# Altium schematic sheet Connector.SchDoc
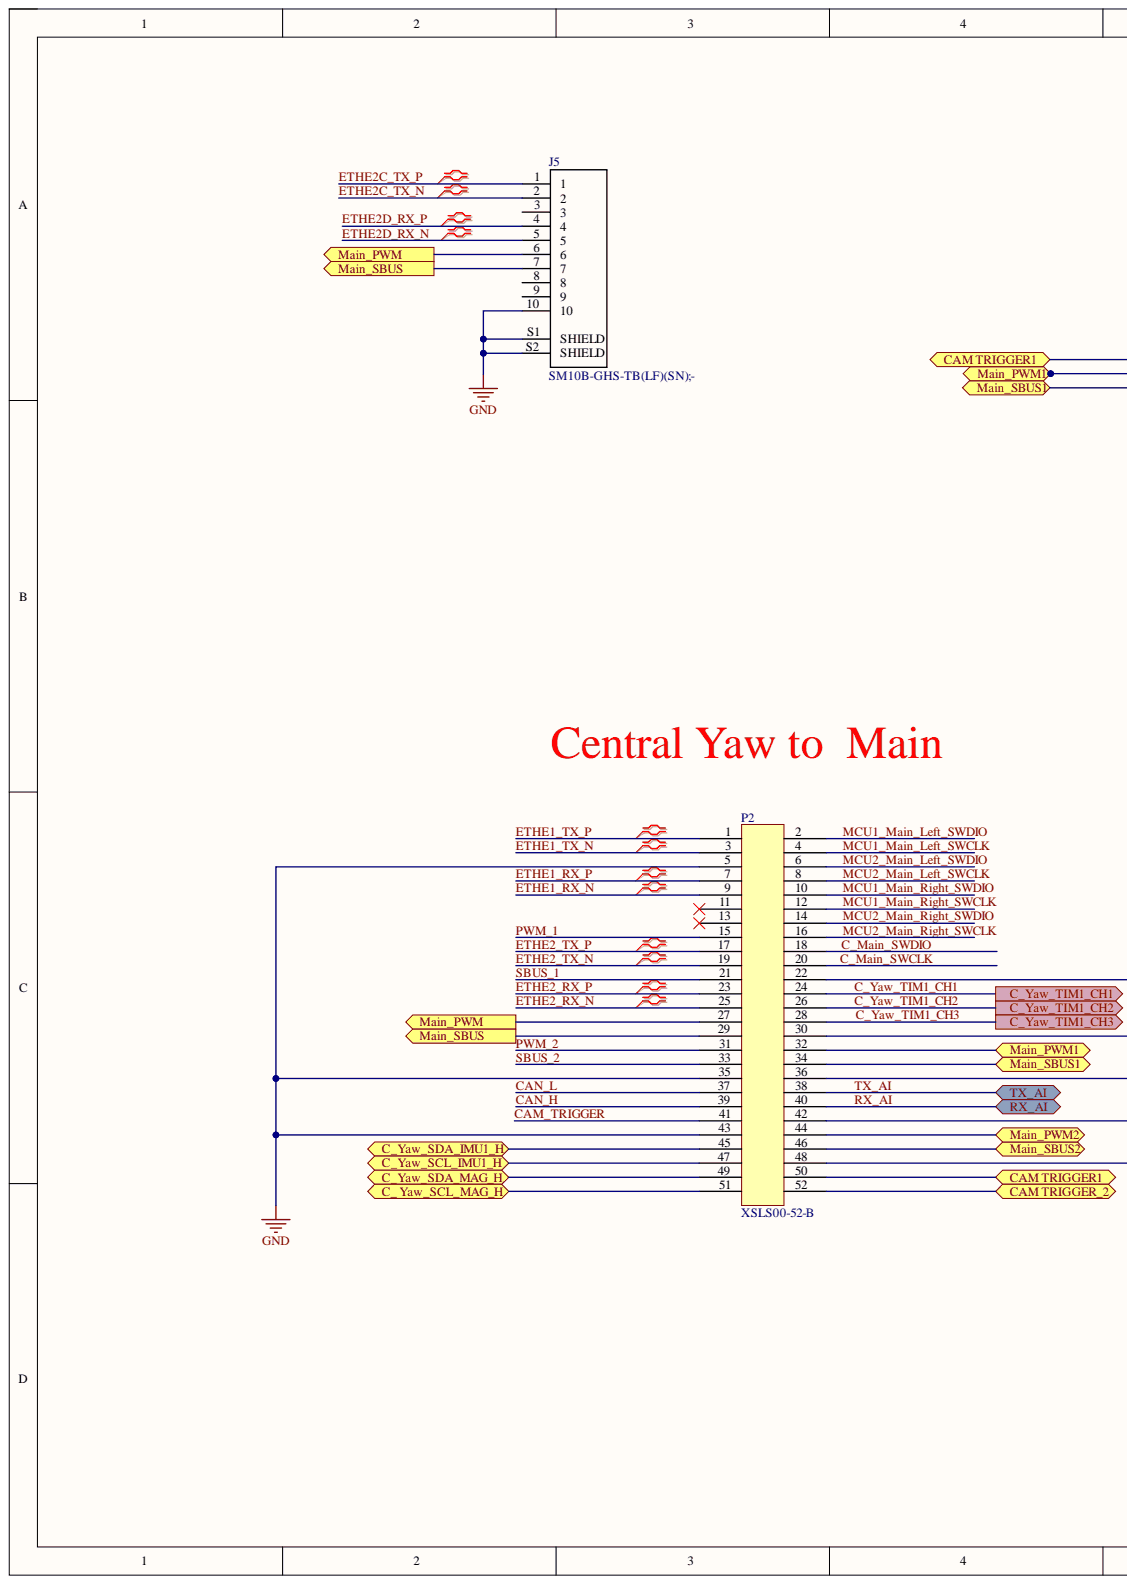
[diagram p0: Connector.SchDoc, left]
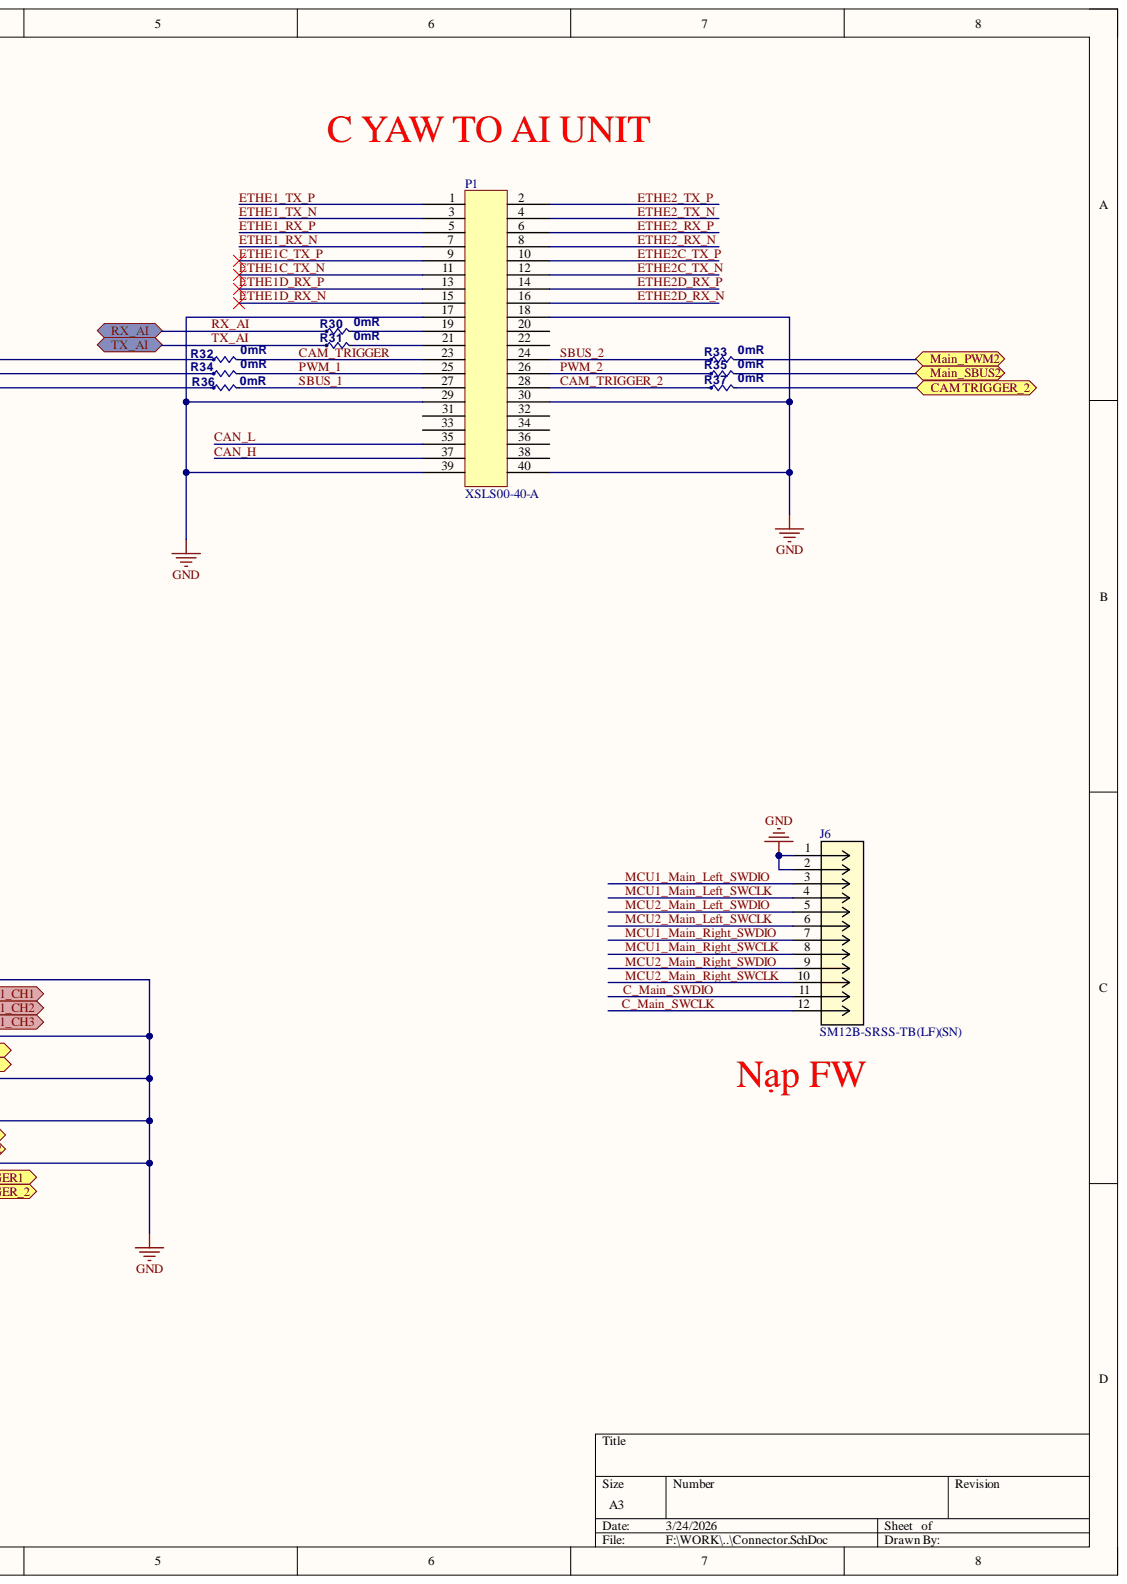
[diagram p1: Connector.SchDoc, right]

## Parts
J5  SM10B-GHS-TB(LF)(SN);-  [SM10B-GHS-TB(LF)(SN)]
J6  SM12B-SRSS-TB(LF)(SN)  [CONN_SM12B-SRSS-TBLFSN_JST]
P1  XSLS00-40-A  [XSLS00-40-A]
P2  XSLS00-52-B  [XSLS00-52-B]
R30  Resistance: 0mR  [RES0402]  (hidden comment: RC0402JR-070RL)
R31  Resistance: 0mR  [RES0402]  (hidden comment: RC0402JR-070RL)
R32  Resistance: 0mR  [RES0402]  (hidden comment: RC0402JR-070RL)
R33  Resistance: 0mR  [RES0402]  (hidden comment: RC0402JR-070RL)
R34  Resistance: 0mR  [RES0402]  (hidden comment: RC0402JR-070RL)
R35  Resistance: 0mR  [RES0402]  (hidden comment: RC0402JR-070RL)
R36  Resistance: 0mR  [RES0402]  (hidden comment: RC0402JR-070RL)
R37  Resistance: 0mR  [RES0402]  (hidden comment: RC0402JR-070RL)

## Ports
C_ Yaw_SCL_MAG_H, C_Yaw_SCL_IMU1_H, C_Yaw_SDA_IMU1_H, C_Yaw_SDA_MAG_H, C_Yaw_TIM1_CH1, C_Yaw_TIM1_CH2, C_Yaw_TIM1_CH3, CAM TRIGGER1, CAM TRIGGER_2, Main_PWM, Main_PWM1, Main_PWM2, Main_SBUS, Main_SBUS1, Main_SBUS2, RX_AI, TX_AI

## Nets
GND: J5.10 J5.S1(SHIELD) J5.S2(SHIELD) J6.1 J6.2 P1.17 P1.18 P1.29 P1.30 P1.39 P1.40 P2.5 P2.22 P2.30 P2.35 P2.36 P2.42 P2.43 P2.48
C_ Yaw_SCL_MAG_H: P2.51 ; port C_ Yaw_SCL_MAG_H
C_Main_SWCLK: J6.12 P2.20
C_Main_SWDIO: J6.11 P2.18
C_Yaw_SCL_IMU1_H: P2.47 ; port C_Yaw_SCL_IMU1_H
C_Yaw_SDA_IMU1_H: P2.45 ; port C_Yaw_SDA_IMU1_H
C_Yaw_SDA_MAG_H: P2.49 ; port C_Yaw_SDA_MAG_H
C_Yaw_TIM1_CH1: P2.24 ; port C_Yaw_TIM1_CH1
C_Yaw_TIM1_CH2: P2.26 ; port C_Yaw_TIM1_CH2
C_Yaw_TIM1_CH3: P2.28 ; port C_Yaw_TIM1_CH3
CAM TRIGGER1: P2.50 R32.1 ; port CAM TRIGGER1
CAM TRIGGER_2: P2.52 R37.2 ; port CAM TRIGGER_2
CAM_TRIGGER: P1.23 P2.41 R32.2
CAM_TRIGGER_2: P1.28 R37.1
CAN_H: P1.37 P2.39
CAN_L: P1.35 P2.37
ETHE1_RX_N: P1.7 P2.9
ETHE1_RX_P: P1.5 P2.7
ETHE1_TX_N: P1.3 P2.3
ETHE1_TX_P: P1.1 P2.1
ETHE1C_TX_N: P1.11
ETHE1C_TX_P: P1.9
ETHE1D_RX_N: P1.15
ETHE1D_RX_P: P1.13
ETHE2_RX_N: P1.8 P2.25
ETHE2_RX_P: P1.6 P2.23
ETHE2_TX_N: P1.4 P2.19
ETHE2_TX_P: P1.2 P2.17
ETHE2C_TX_N: J5.2 P1.12
ETHE2C_TX_P: J5.1 P1.10
ETHE2D_RX_N: J5.5 P1.16
ETHE2D_RX_P: J5.4 P1.14
Main_PWM: J5.6 P2.27 ; port Main_PWM
Main_PWM1: P2.32 R34.1 ; port Main_PWM1
Main_PWM2: P2.44 R33.2 ; port Main_PWM2
Main_SBUS: J5.7 P2.29 ; port Main_SBUS
Main_SBUS1: P2.34 R36.1 ; port Main_SBUS1
Main_SBUS2: P2.46 R35.2 ; port Main_SBUS2
MCU1_Main_Left_SWCLK: J6.4 P2.4
MCU1_Main_Left_SWDIO: J6.3 P2.2
MCU1_Main_Right_SWCLK: J6.8 P2.12
MCU1_Main_Right_SWDIO: J6.7 P2.10
MCU2_Main_Left_SWCLK: J6.6 P2.8
MCU2_Main_Left_SWDIO: J6.5 P2.6
MCU2_Main_Right_SWCLK: J6.10 P2.16
MCU2_Main_Right_SWDIO: J6.9 P2.14
PWM_1: P1.25 P2.15 R34.2
PWM_2: P1.26 P2.31 R35.1
RX_AI: P2.40 R30.1 ; port RX_AI
SBUS_1: P1.27 P2.21 R36.2
SBUS_2: P1.24 P2.33 R33.1
TX_AI: P2.38 R31.1 ; port TX_AI
NetP1_19: P1.19 R30.2
NetP1_21: P1.21 R31.2

Not connected: J5 3 8 9; P1 20 22 31 32 33 34 36 38; P2 11 13

## Library data of the parts
J5 = SM10B-GHS-TB(LF)(SN);-: CONN HEADER GH SIDE 10POS 1.25MM; Manufacturer: JST; Connector Type: Male Pin; Number of Positions: 10; Pitch: 0.049inch; Color: Natural; Component Kind: Standard; ComponentLink1Description: http://www.jst-mfg.com/product/pdf/eng/eGH.pdf?src-supplier=Digi-Key; ComponentLink1URL: http://www.jst-mfg.com/product/pdf/eng/eGH.pdf?src-supplier=Digi-Key; Contact Plating: Tin; Fastening Type: Latch; Manufacturer Part Number: SM10B-GHS-TB(LF)(SN);-; Mount: Surface Mount; Number of Rows: 1; Package Quantity: 1; Packaging: Tape and Reel; RoHS: Yes; Stock 1: 0; Supplier 1: Digi-Key; Supplier Part Number 1: 455-1572-2-ND; Termination: Solder; Library Name: rtr.IntLib
J6 = SM12B-SRSS-TBLFSN: Description; Manufacturer_Name: JST; Component Kind: Standard; Manufacturer_Part_Number: SM12B-SRSS-TB(LF)(SN); RefDes: RefDes; Type: Type; Copyright: Copyright (C) 2025 Ultra Librarian. All rights reserved.; Library Name: RTR.SchLib
R30-R37 = CMP-00848-1: RES SMD 0 OHM JUMPER 1/16W 0402; Manufacturer 1: Yageo; Max Power Dissipation: 63mW; Power Rating: 62.5mW; Temperature Coefficient: 200ppm/°C; Tolerance: 5%; Voltage Rating: 50V; Case Code (Imperial): 0402; Case Code (Metric): 1005; Case/Package: 0402; Component Kind: Standard; Composition: Thick Film; Contact Plating: Tin,Matte; Depth: 0.5mm; Features: Moisture Resistant; Height: 0.016inch; Lead Free: Lead Free; Length: 1mm; Manufacturer Part Number 1: RC0402JR-070RL; Max Operating Temperature: 155°C; Military Standard: Not; Min Operating Temperature: -55°C; Number of Terminations: 2; Package Quantity: 1; Packaging: Tape and Reel; Radiation Hardening: No; REACH SVHC: Unknown; Termination: SMD/SMT; Width: 0.02inch; Library Name: rtr.IntLib
P1 = XSLS00-40-A: Component Kind: Standard; Library Name: Hera_Sight_PixyU2.IntLib
P2 = XSLS00-52-B: Component Kind: Standard; Library Name: Hera_Sight_PixyU2.IntLib
